# Altium schematic sheet Main.SchDoc
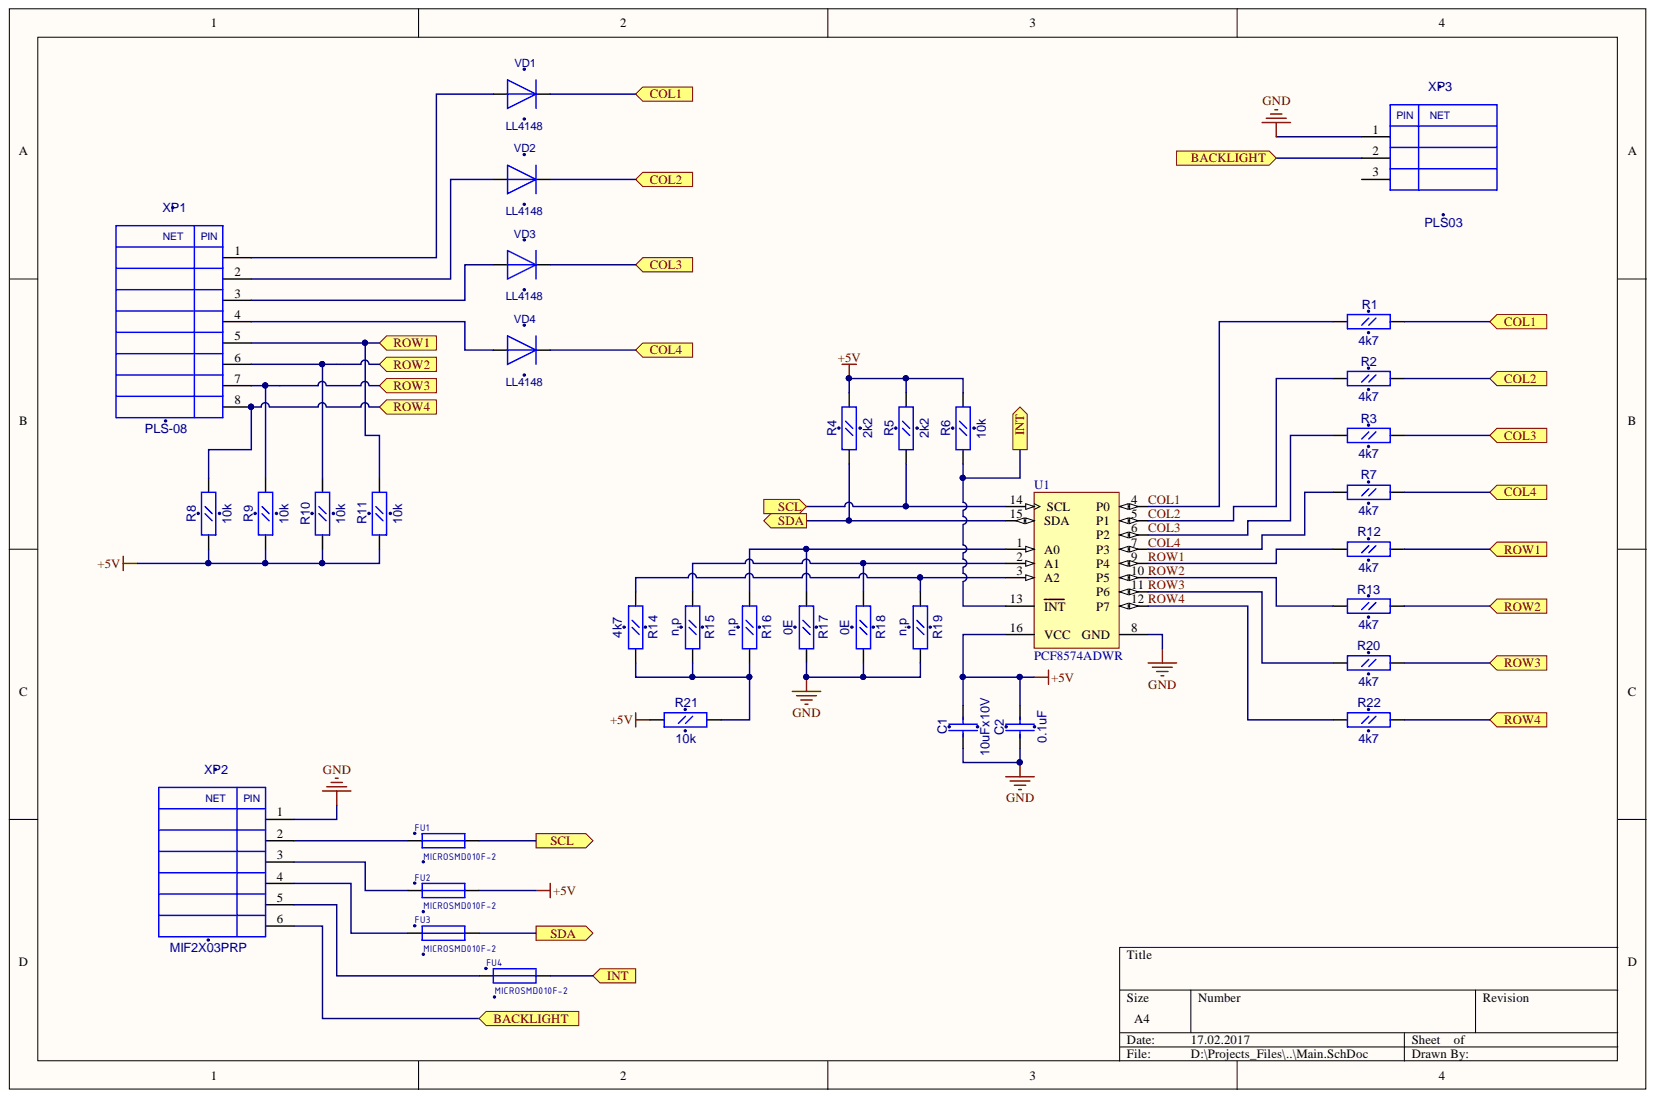
[diagram p0: Main.SchDoc]

## Parts
C1  10uFx10V  [CC0805]
C2  0.1uF  [CC0603]
FU1  MICROSMD010F-2  [RC1210]
FU2  MICROSMD010F-2  [RC1210]
FU3  MICROSMD010F-2  [RC1210]
FU4  MICROSMD010F-2  [RC1210]
R1  4k7  [RC0603]
R2  4k7  [RC0603]
R3  4k7  [RC0603]
R4  2k2  [RC0603]
R5  2k2  [RC0603]
R6  10k  [RC0603]
R7  4k7  [RC0603]
R8  10k  [RC0603]
R9  10k  [RC0603]
R10  10k  [RC0603]
R11  10k  [RC0603]
R12  4k7  [RC0603]
R13  4k7  [RC0603]
R14  4k7  [RC0603]
R15  n.p  [RC0603]
R16  n.p  [RC0603]
R17  0E  [RC0603]
R18  0E  [RC0603]
R19  n.p  [RC0603]
R20  4k7  [RC0603]
R21  10k  [RC0603]
R22  4k7  [RC0603]
U1  PCF8574ADWR  [DW16_N]
VD1  LL4148  [SOD-80]
VD2  LL4148  [SOD-80]
VD3  LL4148  [SOD-80]
VD4  LL4148  [SOD-80]
XP1  PLS-08  [PLS-08]
XP2  MIF2X03PRP  [MIF2X03PRP]
XP3  PLS03  [PLS-03]

## Ports
BACKLIGHT, COL1, COL2, COL3, COL4, INT, ROW1, ROW2, ROW3, ROW4, SCL, SDA

## Nets
+5V: C1.2 C2.2 FU2.2 R4.2 R5.2 R6.2 R8.1 R9.1 R10.1 R11.1 R21.1 U1.16(VCC)
GND: C1.1 C2.1 R17.2 R18.2 R19.2 U1.8(GND) XP2.1 XP3.1
BACKLIGHT: XP2.6 XP3.2 ; port BACKLIGHT
COL1: R1.1 U1.4(P0)
COL2: R2.1 U1.5(P1)
COL3: R3.1 U1.6(P2)
COL4: R7.1 U1.7(P3)
INT: FU4.2 R6.1 U1.13(INT) ; port INT
ROW1: R12.1 U1.9(P4)
ROW2: R13.1 U1.10(P5)
ROW3: R20.1 U1.11(P6)
ROW4: R22.1 U1.12(P7)
SCL: FU1.2 R5.1 U1.14(SCL) ; port SCL
SDA: FU3.2 R4.1 U1.15(SDA) ; port SDA
NetFU1_1: FU1.1 XP2.2
NetFU2_1: FU2.1 XP2.3
NetFU3_1: FU3.1 XP2.4
NetFU4_1: FU4.1 XP2.5
NetR1_2: R1.2 VD1.2 ; port COL1
NetR2_2: R2.2 VD2.2 ; port COL2
NetR3_2: R3.2 VD3.2 ; port COL3
NetR7_2: R7.2 VD4.2 ; port COL4
NetR8_2: R8.2 R22.2 XP1.8 ; port ROW4
NetR9_2: R9.2 R20.2 XP1.7 ; port ROW3
NetR10_2: R10.2 R13.2 XP1.6 ; port ROW2
NetR11_2: R11.2 R12.2 XP1.5 ; port ROW1
NetR14_1: R14.1 R19.1 U1.3(A2)
NetR14_2: R14.2 R15.2 R16.2 R21.2
NetR15_1: R15.1 R18.1 U1.2(A1)
NetR16_1: R16.1 R17.1 U1.1(A0)
NetVD1_1: VD1.1 XP1.1
NetVD2_1: VD2.1 XP1.2
NetVD3_1: VD3.1 XP1.3
NetVD4_1: VD4.1 XP1.4

Not connected: XP3 3

## Library data of the parts
C1 = CC0805: Component Kind: Standard; Description: CC0805; Value: 10uFx10V; Library Name: gprs_modem_2.SchLib
C2 = CC0603: Component Kind: Standard; Description: CC0603; Value: 0.1uF; Library Name: gprs_modem_2.SchLib
FU1-FU4 = MICROSMD: Component Kind: Standard; Description: MICROSMD; Value: MICROSMD010F-2; Library Name: gprs_modem_2.SchLib
R1-R22 = RC0603: Component Kind: Standard; Description: RC0603; Library Name: gprs_modem_2.SchLib
  R1-R3 R7 R12-R14 R20 R22: Value: 4k7
  R4 R5: Value: 2k2
  R6 R8-R11 R21: Value: 10k
  R15 R16 R19: Value: n.p
  R17 R18: Value: 0E
U1 = PCF8574ADWR: Remote 8-Bit I/O Expander for I2C-Bus, 2.5 to 6.0 V, -40 to 85 degC, 16-pin SOIC (DW), Green (RoHS & no Sb/Br); Manufacturer: Texas Instruments; # of I/Os: 8; fSCLK(Max)(MHz): 0.1; I2C Address: 0111 xxx; Interrupt Output: Yes; Max Frequency(kHz): 100; SubFamily: I2C IO Expander; VCC(Max)(V): 6; VCC(Min)(V): 2.5; Voltage Nodes(V): 5, 3.3; Code_JEDEC: MS-013-AA; Component Kind: Standard; ComponentLink1Description: Datasheet; ComponentLink1URL: http://www.ti.com/general/docs/lit/getliterature.tsp?genericPartNumber=PCF8574A&fileType=pdf; ComponentLink2Description: Package Specification; ComponentLink2URL: http://www.ti.com/litv/pdf/msoi003g; DatasheetVersion: SCPS069D; Eco-Plan: Green (RoHS & no Sb/Br); Library Name: Texas Instruments Interface I2C.IntLib; Mounting Technology: Surface Mount; PackageDescription: 16-Pin Small Outline Integrated Circuit, 10.3 x 7.5 mm, Pitch 1.27 mm Pitch; PackageReference: DW16; PackageVersion: revG, Jan-2011; PartNumber: PCF8574ADWR; Push-Pull I/O Type: Yes; Reset Input: No; Supplier 1: Digi-Key; Supplier 2: Digi-Key; Supplier 3: Digi-Key; Supplier 4: Newark; Supplier 5: Mouser; Supplier Part Number 1: 296-13105-2-ND; Supplier Part Number 2: 296-13105-1-ND; Supplier Part Number 3: 296-13105-6-ND; Supplier Part Number 4: 75C5233; Supplier Part Number 5: 595-PCF8574ADWR; Vcc range(V): 2.5 to 6.0
VD1-VD4 = LL4148: Component Kind: Standard; Description: LL4148; Library Name: gprs_modem_2.SchLib
XP1 = PLS-08: Component Kind: Standard; Description: PLS-08; Library Name: gprs_modem_2.SchLib
XP2 = MIF2X03PRP: Component Kind: Standard; Description: MIF2X03PRP; Library Name: gprs_modem_2.SchLib
XP3 = PLS03: Component Kind: Standard; Description: PLS03; Library Name: gprs_modem_2.SchLib
